# Altium schematic sheet Comm.SCHDOC(Comm)
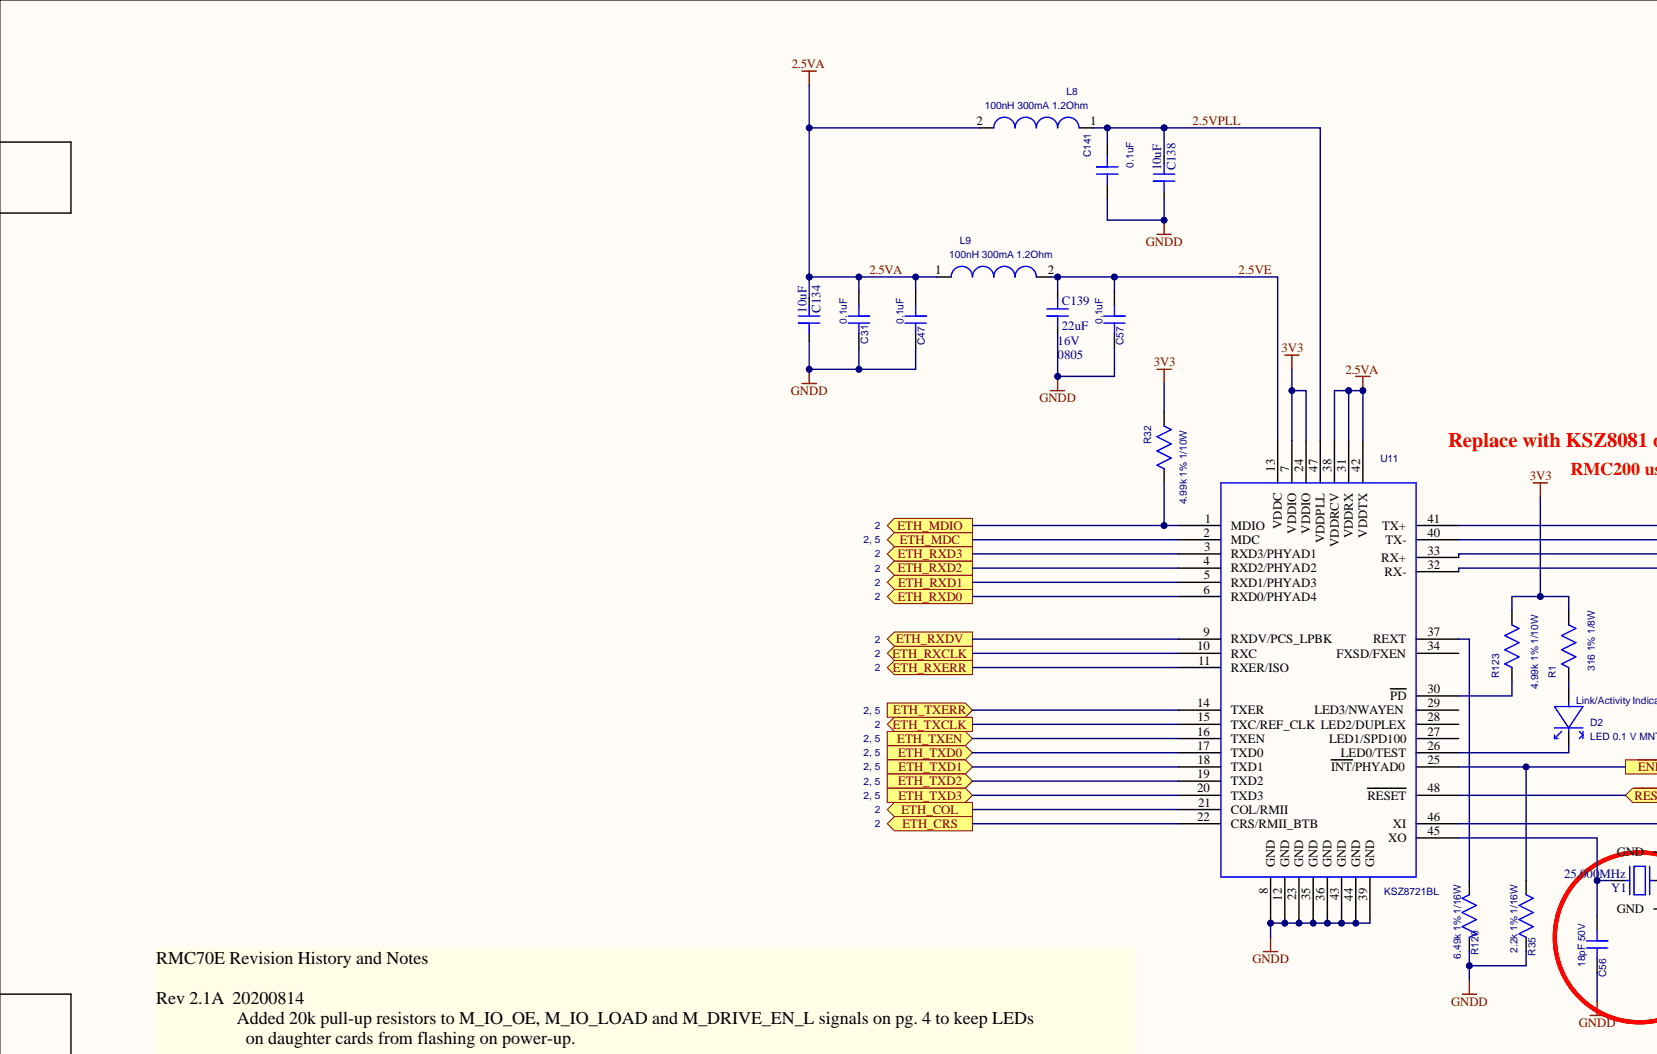
[diagram p0: Comm.SCHDOC, top left]
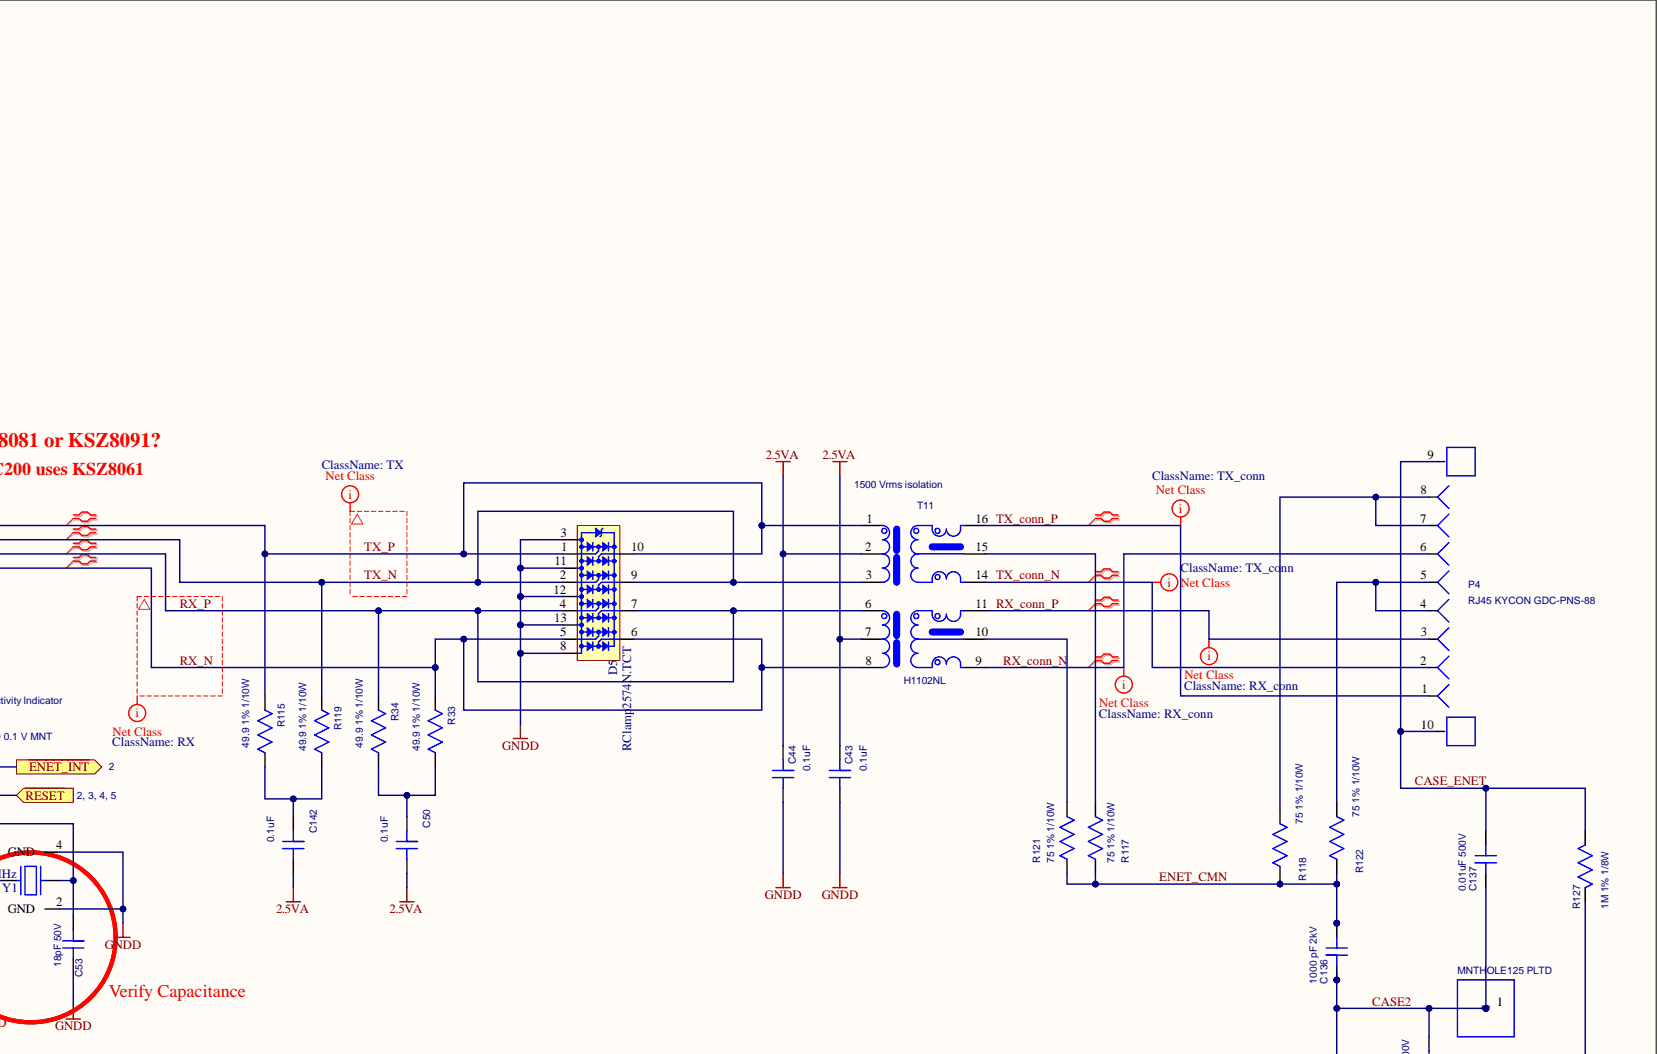
[diagram p1: Comm.SCHDOC, top right]
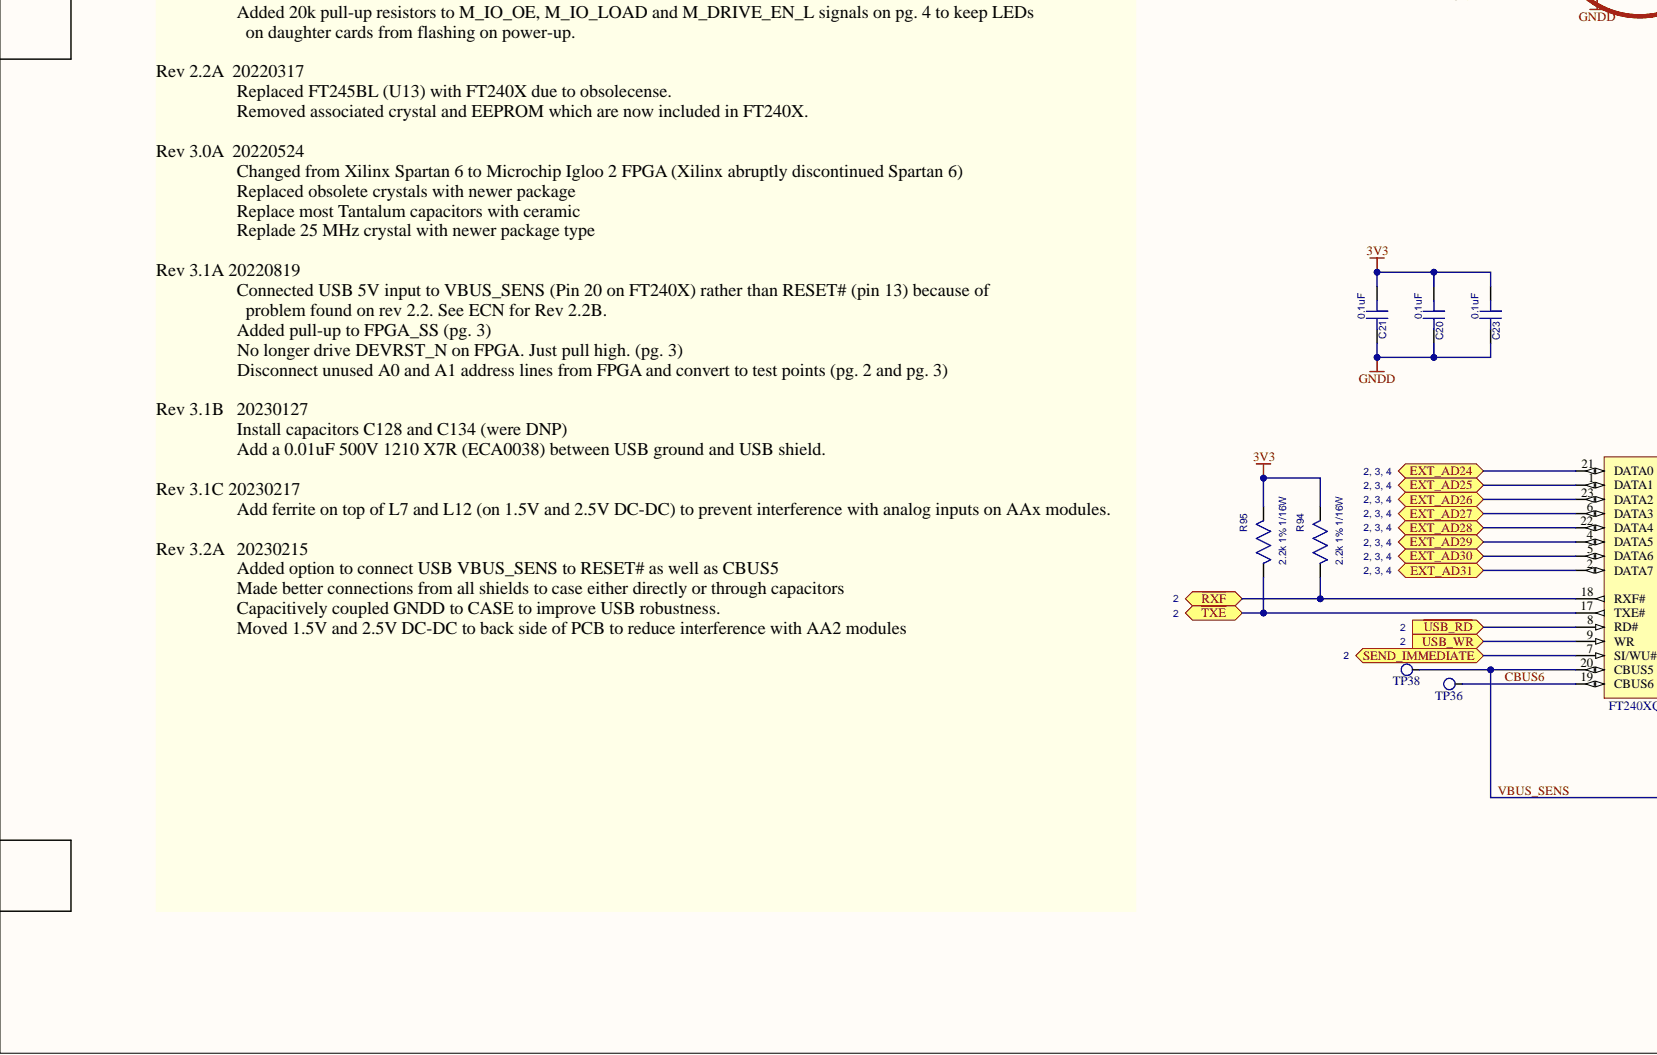
[diagram p2: Comm.SCHDOC, bottom left]
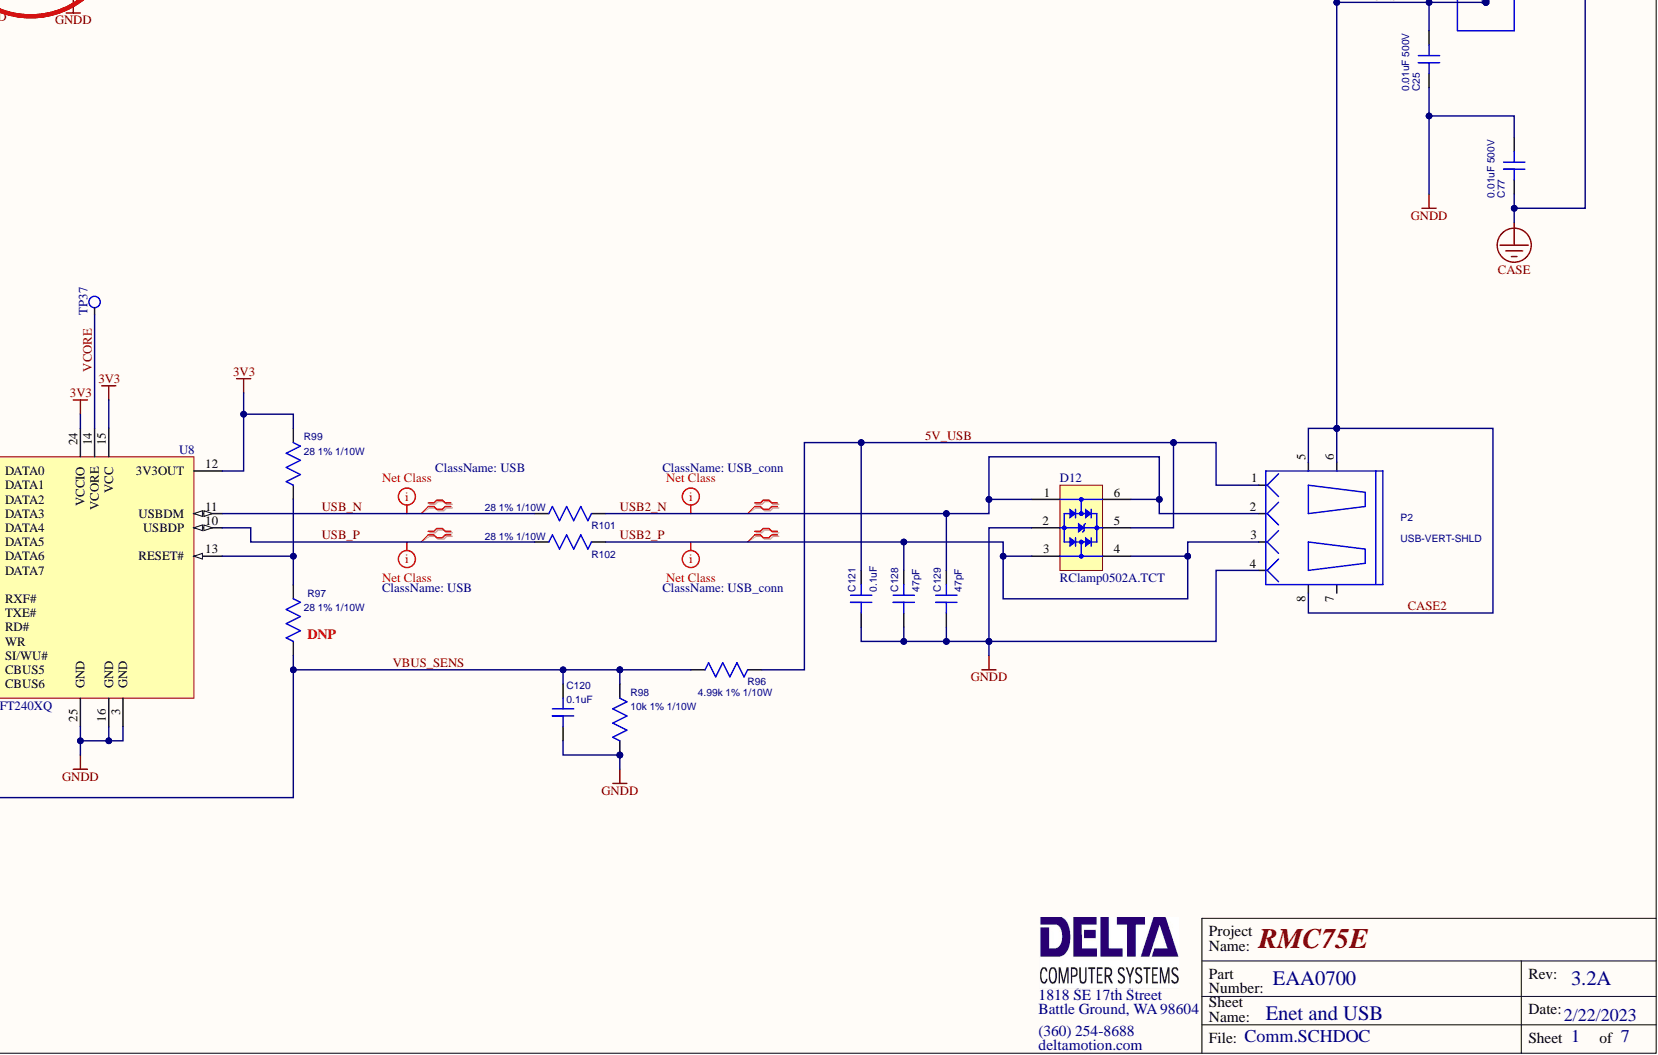
[diagram p3: Comm.SCHDOC, bottom right]

## Parts
C20  0.1uF  [CC0603]
C21  0.1uF  [CC0603]
C23  0.1uF  [CC0603]
C25  0.01uF 500V  [CC1210]
C31  0.1uF  [CC0603]
C43  0.1uF  [CC0603]
C44  0.1uF  [CC0603]
C47  0.1uF  [CC0603]
C50  0.1uF  [CC0603]
C53  18pF 50V  [CC0603]
C56  18pF 50V  [CC0603]
C57  0.1uF  [CC0603]
C77  0.01uF 500V  [CC1210]
C120  0.1uF  [CC0603]
C121  0.1uF  [CC0603]
C128  47pF  [CC0603]
C129  47pF  [CC0603]
C134  10uF  [CAPC2012-N [0805]]
C136  1000 pF 2kV  [CC1210]
C137  0.01uF 500V  [CC1210]
C138  10uF  [CAPC2012-N [0805]]
C139  22uF  CaseSize: 0805  RatedVoltage: 16V  [CAPC2012-N [0805]]
C141  0.1uF  [CC0603]
C142  0.1uF  [CC0603]
D2  LED 0.1 V MNT  [LED 0.1 VERT]
D5  RClamp2574N.TCT  [RCLAMP2574N [SEMTECH SLP3020N10] - duplicate]
D12  RClamp0502A.TCT  [RCLAMP0502A [SEMTECH SC-89]]
L8  100nH 300mA 1.2Ohm  [CC0603]
L9  100nH 300mA 1.2Ohm  [CC0603]
M2  MNTHOLE125 PLTD  [MNTHLE-PLTD]  (no BOM)
P2  USB-VERT-SHLD  [USB-VERT]
P4  RJ45 KYCON GDC-PNS-88  [KYCON GDC-PNS-88]
R1  316 1% 1/8W  [CC0805]
R32  4.99k 1% 1/10W  [CC0603]
R33  49.9 1% 1/10W  [CC0603]
R34  49.9 1% 1/10W  [CC0603]
R35  2.2k 1% 1/16W  [CC0603]
R94  2.2k 1% 1/16W  [CC0603]
R95  2.2k 1% 1/16W  [CC0603]
R96  4.99k 1% 1/10W  [CC0603]
R97  28 1% 1/10W  [CC0603]  (no BOM)
R98  10k 1% 1/10W  [CC0603]
R99  28 1% 1/10W  [CC0603]
R101  28 1% 1/10W  [CC0603]
R102  28 1% 1/10W  [CC0603]
R115  49.9 1% 1/10W  [CC0603]
R117  75 1% 1/10W  [CC0603]
R118  75 1% 1/10W  [CC0603]
R119  49.9 1% 1/10W  [CC0603]
R120  6.49k 1% 1/16W  [CC0603]
R121  75 1% 1/10W  [CC0603]
R122  75 1% 1/10W  [CC0603]
R123  4.99k 1% 1/10W  [CC0603]
R127  1M 1% 1/8W  [CC0805]
T11  H1102NL  [SOIC16-MAG]
TP36  Test Point  [TP_SMT_RND_25]  (value not drawn)  (no BOM)
TP37  Test Point  [TP_SMT_RND_25]  (value not drawn)  (no BOM)
TP38  Test Point  [TP_SMT_RND_25]  (value not drawn)  (no BOM)
U8  FT240XQ  [VQFN-24N [MO-220 VGGD-8]]
U11  KSZ8721BL  [TQFP48]
Y1  25.000MHz  [3.2x2.5mm-4]

## Ports
ETH_COL, ETH_CRS, ETH_MDC, ETH_MDIO, ETH_RXCLK, ETH_RXD0, ETH_RXD1, ETH_RXD2, ETH_RXD3, ETH_RXDV, ETH_RXERR, ETH_TXCLK, ETH_TXD0, ETH_TXD1, ETH_TXD2, ETH_TXD3, ETH_TXEN, ETH_TXERR, EXT_AD24, EXT_AD25, EXT_AD26, EXT_AD27, EXT_AD28, EXT_AD29, EXT_AD30, EXT_AD31, SEND_IMMEDIATE, USB_WR, ~{ENET_INT}, ~{RESET}, ~{RXF}, ~{TXE}, ~{USB_RD}

## Nets
3V3: C20.2 C21.2 C23.2 R1.2 R32.2 R94.2 R95.2 R99.1 R123.2 U8.12(3V3OUT) U8.15(VCC) U8.24(VCCIO) U11.7(VDDIO) U11.24(VDDIO)
CASE: C77.2 R127.2
GNDD: C20.1 C21.1 C23.1 C25.2 C31.1 C43.1 C44.1 C47.1 C53.1 C56.1 C57.1 C77.1 C120.2 C121.2 C128.2 C129.2 C134.2 C138.2 C139.2 C141.1 D5.3 D5.8 D5.11 D5.12 D5.13 D12.2 P2.4 R35.1 R98.2 R120.2 U8.3(GND) U8.16(GND) U8.25(GND) U11.8(GND) U11.12(GND) U11.23(GND) U11.35(GND) U11.36(GND) U11.39(GND) U11.43(GND) U11.44(GND) Y1.2(GND) Y1.4(GND)
2.5VA: C31.2 C43.2 C44.2 C47.2 C50.1 C134.1 C142.1 L8.2 L9.1 T11.2 T11.7 U11.31(VDDRX) U11.38(VDDRCV) U11.42(VDDTX)
2.5VE: C57.2 C139.1 L9.2 U11.13(VDDC)
2.5VPLL: C138.1 C141.2 L8.1 U11.47(VDDPLL)
5V_USB: C121.1 D12.5 P2.1 R96.2
CASE2: C25.1 C136.2 C137.2 M2.1 P2.5 P2.6 P2.8
CASE_ENET: C137.1 P4.9 P4.10 R127.1
CBUS6: TP36.1 U8.19(CBUS6)
~{ENET_INT}: R35.2 U11.25(INT/PHYAD0) ; port ~{ENET_INT}
ENET_CMN: C136.1 R117.1 R118.1 R121.1 R122.1
ETH_COL: U11.21(COL/RMII) ; port ETH_COL
ETH_CRS: U11.22(CRS/RMII_BTB) ; port ETH_CRS
ETH_MDC: U11.2(MDC) ; port ETH_MDC
ETH_MDIO: R32.1 U11.1(MDIO) ; port ETH_MDIO
ETH_RXCLK: U11.10(RXC) ; port ETH_RXCLK
ETH_RXD0: U11.6(RXD0/PHYAD4) ; port ETH_RXD0
ETH_RXD1: U11.5(RXD1/PHYAD3) ; port ETH_RXD1
ETH_RXD2: U11.4(RXD2/PHYAD2) ; port ETH_RXD2
ETH_RXD3: U11.3(RXD3/PHYAD1) ; port ETH_RXD3
ETH_RXDV: U11.9(RXDV/PCS_LPBK) ; port ETH_RXDV
ETH_RXERR: U11.11(RXER/ISO) ; port ETH_RXERR
ETH_TXCLK: U11.15(TXC/REF_CLK) ; port ETH_TXCLK
ETH_TXD0: U11.17(TXD0) ; port ETH_TXD0
ETH_TXD1: U11.18(TXD1) ; port ETH_TXD1
ETH_TXD2: U11.19(TXD2) ; port ETH_TXD2
ETH_TXD3: U11.20(TXD3) ; port ETH_TXD3
ETH_TXEN: U11.16(TXEN) ; port ETH_TXEN
ETH_TXERR: U11.14(TXER) ; port ETH_TXERR
EXT_AD24: U8.21(DATA0) ; port EXT_AD24
EXT_AD25: U8.1(DATA1) ; port EXT_AD25
EXT_AD26: U8.23(DATA2) ; port EXT_AD26
EXT_AD27: U8.6(DATA3) ; port EXT_AD27
EXT_AD28: U8.22(DATA4) ; port EXT_AD28
EXT_AD29: U8.4(DATA5) ; port EXT_AD29
EXT_AD30: U8.5(DATA6) ; port EXT_AD30
EXT_AD31: U8.2(DATA7) ; port EXT_AD31
~{RESET}: U11.48(RESET) ; port ~{RESET}
~{RXF}: R94.1 U8.18(RXF#) ; port ~{RXF}
RX_conn_N: P4.6 T11.9
RX_conn_P: P4.3 T11.11
RX_N: D5.5 D5.6 R33.1 T11.8 U11.32(RX-)
RX_P: D5.4 D5.7 R34.2 T11.6 U11.33(RX+)
SEND_IMMEDIATE: U8.7(SI/WU#) ; port SEND_IMMEDIATE
~{TXE}: R95.1 U8.17(TXE#) ; port ~{TXE}
TX_conn_N: P4.2 T11.14
TX_conn_P: P4.1 T11.16
TX_N: D5.2 D5.9 R119.1 T11.3 U11.40(TX-)
TX_P: D5.1 D5.10 R115.2 T11.1 U11.41(TX+)
~{USB_RD}: U8.8(RD#) ; port ~{USB_RD}
USB2_N: C129.1 D12.1 D12.6 P2.2 R101.2
USB2_P: C128.1 D12.3 D12.4 P2.3 R102.2
USB_N: R101.1 U8.11(USBDM)
USB_P: R102.1 U8.10(USBDP)
USB_WR: U8.9(WR) ; port USB_WR
VBUS_SENS: C120.1 R96.1 R97.2 R98.1 TP38.1 U8.20(CBUS5)
VCORE: TP37.1 U8.14(VCORE)
NetC50_2: C50.2 R33.2 R34.1
NetC53_2: C53.2 U11.46(XI) Y1.1
NetC56_2: C56.2 U11.45(XO) Y1.3
NetC142_2: C142.2 R115.1 R119.2
NetD2_1: D2.1 R1.1
NetD2_2: D2.2 U11.26(LED0/TEST)
NetP4_4: P4.4 P4.5 R122.2
NetP4_7: P4.7 P4.8 R118.2
NetR97_1: R97.1 R99.2 U8.13(RESET#)
NetR117_2: R117.2 T11.15
NetR120_1: R120.1 U11.37(REXT)
NetR121_2: R121.2 T11.10
NetR123_1: R123.1 U11.30(PD)

Not connected: P2 7; U11 27(LED1/SPD100) 28(LED2/DUPLEX) 29(LED3/NWAYEN) 34(FXSD/FXEN)

## Library data of the parts
C20 C21 C23 C31 C43 C44 C47 C50 C57 C120 C121 C141 C142 = CAPNP_SMT0603: Cap Cer 0.1uF 25V 10% 0603 X7R; Manufacturer: Venkel; PartNumber: C0603X7R250-104KNE; Component Kind: Standard; Value: 0.1uF
C25 C77 C137 = CAPNP_SMT1210: Cap Cer 0.01uF 500V 10% 1210 X7R; Manufacturer: Venkel; PartNumber: C1210X7R501-103KNE; Component Kind: Standard; Value: 0.01uF 500V
C53 C56 = CAPNP_SMT0603: Cap Cer 18pF 50V 5% 0603 C0G; Manufacturer: Venkel; PartNumber: C0603C0G500-180JNE; Component Kind: Standard; Value: 18pF 50V
C128 C129 = CAPNP_SMT0603: Cap Cer 47pF 50V 5% 0603 C0G; Manufacturer: Venkel; PartNumber: C0603C0G500-470JNE; Component Kind: Standard; Value: 47pF
C134 C138 = Cap Ceramic 10uF 10.0% 10V 0805: Cap Cer 10uF 10V 10% 0805 X7R; ManufacturerAlt1: Various; Database Table Name: CapacitorTable$; Notes: ECA0025; Tolerance: 10.0%; Type: Ceramic X7R; CaseSize: 0805; Component Kind: Standard; ComponentType: Capacitor; DateUpdated: 02/22/2023; Library Name: Capacitor.DbLib; PartNumberAlt1: Various; RatedVoltage: 10V; Value: 10uF
C136 = CAPNP_SMT1210: Cap Cer 1000pF 2kV 10% 1210 X7R; Manufacturer: Venkel; PartNumber: C1210X7R202-102KNE; Notes: ECA0108; Component Kind: Standard; Value: 1000 pF 2kV
C139 = Capacitor - Non-polarized: Cap Ceramic X5R 22uF 20% 16V 0805; Manufacturer: Venkel; ManufacturerAlt1: Various; PartNumber: C0805X5R160-226MNE; Component Kind: Standard; ComponentType: Capacitor; DateUpdated: 03/11/2022; Library Name: Capacitor.IntLib; PartNumberAlt1: Various; Tolerance: 20%; Type: Ceramic X5R; Value: 22uF
D2 = LED 0.1 V MNT: LED Green Diff 3mm Round T1; Manufacturer: Dialight; Component Kind: Standard; PartNumber: HLMP-1790
D5 = RClamp2574N: High power TVS for Ethernet; Manufacturer: Semtech Corporation; Component Kind: Standard; ComponentType: Protection; DateUpdated: 09/26/2011; Library Name: Protection.IntLib; PartNumber: RClamp2574N.TCT
D12 = RClamp0502A: Low Capacitance TVS Array; Manufacturer: Semtech Corporation; Component Kind: Standard; ComponentType: Protection; DateUpdated: 09/26/2011; Library Name: Protection.IntLib; PartNumber: RClamp0502A.TCT
L8 L9 = FERRITE-0603: Inductor Fixed 100nH 300mA 1.2Ohm 1608; Manufacturer: Venkel; PartNumber: LMCI1608-R10KT; Component Kind: Standard; Value: 100nH 300mA 1.2Ohm
P2 = USB-VERT-SHLD: USB Conn Type "B" Shielded Blk ST; Manufacturer: Kycon; PartNumber: KUSBVX-BS1N-B; Component Kind: Standard
P4 = RJ45 KYCON GDC-PNS-88: Mod Jack 8P8C (RJ45) Shielded ST; PartNumber: GDCX-PNS-88; Component Kind: Standard; Manufacturer: Kycon
R1 = RES_GEN_SMT0805: Res 316 1% 1/8W 100ppm 0805; Manufacturer: Venkel; PartNumber: CR0805-8W-3160FT; Component Kind: Standard; Value: 316 1% 1/8W
R32 R96 R123 = RES_GEN_SMT0603: Res 4.99k 1% 1/10W 100ppm 0603; Manufacturer: Venkel; PartNumber: CR0603-10W-4991FT; Component Kind: Standard; Value: 4.99k 1% 1/10W
R33 R34 R115 R119 = RES_GEN_SMT0603: Res 49.9 1% 1/10W 100ppm 0603; Manufacturer: Venkel; PartNumber: CR0603-10W-49R9FT; Component Kind: Standard; Value: 49.9 1% 1/10W
R35 R94 R95 = RES_GEN_SMT0603: Res 2.2k 1% 1/10W 100ppm 0603; Manufacturer: Venkel; PartNumber: CR0603-10W-2201FT; Component Kind: Standard; Value: 2.2k 1% 1/16W
R97 R99 R101 R102 = RES_GEN_SMT0603: Res 28 1% 1/10W 100ppm 0603; Manufacturer: Venkel; PartNumber: CR0603-10W-28R0FT; Value: 28 1% 1/10W
  R99 R101 R102: Component Kind: Standard
R98 = RES_GEN_SMT0603: Res 10k 1% 1/10W 100ppm 0603; Manufacturer: Venkel; PartNumber: CR0603-10W-1002FT; Component Kind: Standard; Value: 10k 1% 1/10W
R117 R118 R121 R122 = RES_GEN_SMT0603: Res 75 1% 1/10W 100ppm 0603; Manufacturer: Venkel; PartNumber: CR0603-10W-75R0FT; Component Kind: Standard; Value: 75 1% 1/10W
R120 = RES_GEN_SMT0603: Res 6.49k 1% 1/10W 100ppm 0603; Manufacturer: Venkel; PartNumber: CR0603-10W-6491FT; Component Kind: Standard; Value: 6.49k 1% 1/16W
R127 = RES_GEN_SMT0805: Res 1M 1% 1/8W 100ppm 0805; Manufacturer: Venkel; PartNumber: CR0805-8W-1004FT; Component Kind: Standard; Value: 1M 1% 1/8W
T11 = H1102NL: Ethernet Transformer 1.5kV 16SOIC; Manufacturer: Pulse; PartNumber: H1102FNL; Component Kind: Standard
TP36-TP38 = Test Point: Generic Test Point; ComponentType: Connector; DateUpdated: 10/19/2010; Library Name: Connector.IntLib
U8 = FT240XQ: USB Peripheral Controller with FIFO interface; Manufacturer: FTDI; Component Kind: Standard; ComponentType: Communication; DateUpdated: 02/03/2022; Library Name: Communication.IntLib; PartNumber: FT240XQ
U11 = KSZ8721BL: Transceiver Phy 10/100 3.3V 48LPFP; Manufacturer: Micrel; PartNumber: KSZ8721BLI; Component Kind: Standard
Y1 = XTAL 3.2x2.5mm: Crystal 25MHz 18pF 30ppm 3.2x2.5; Manufacturer: ECS Inc.; ManufacturerAlt1: Suntsu; PartNumber: ECS-250-18-33-JGN-TR; PartNumberAlt1: SXT32418BB48-25.000MT; Aging: 5 ppm/year; Calibration Mode: Parallel; Drive Level: 100 uW; ESR: 60 Ohms Max; Shunt Capacitance: 5 pF max; Vibration Mode: Fundamental; Component Kind: Standard; ComponentType: Clock; DateUpdated: 11/22/2021; Frequency Tolerance: 30 ppm; Library Name: Clock.IntLib; Load Capacitance: 18 pF; Temperature Tolerance: 30 ppm; Value: 25.000MHz
M2 = MNTHOLE125 PLTD: Description: MNTHOLE125 PLTD
